# Altium schematic sheet 04-Front Panel SFP_2.SchDoc("04-Front Panel SFP_2")
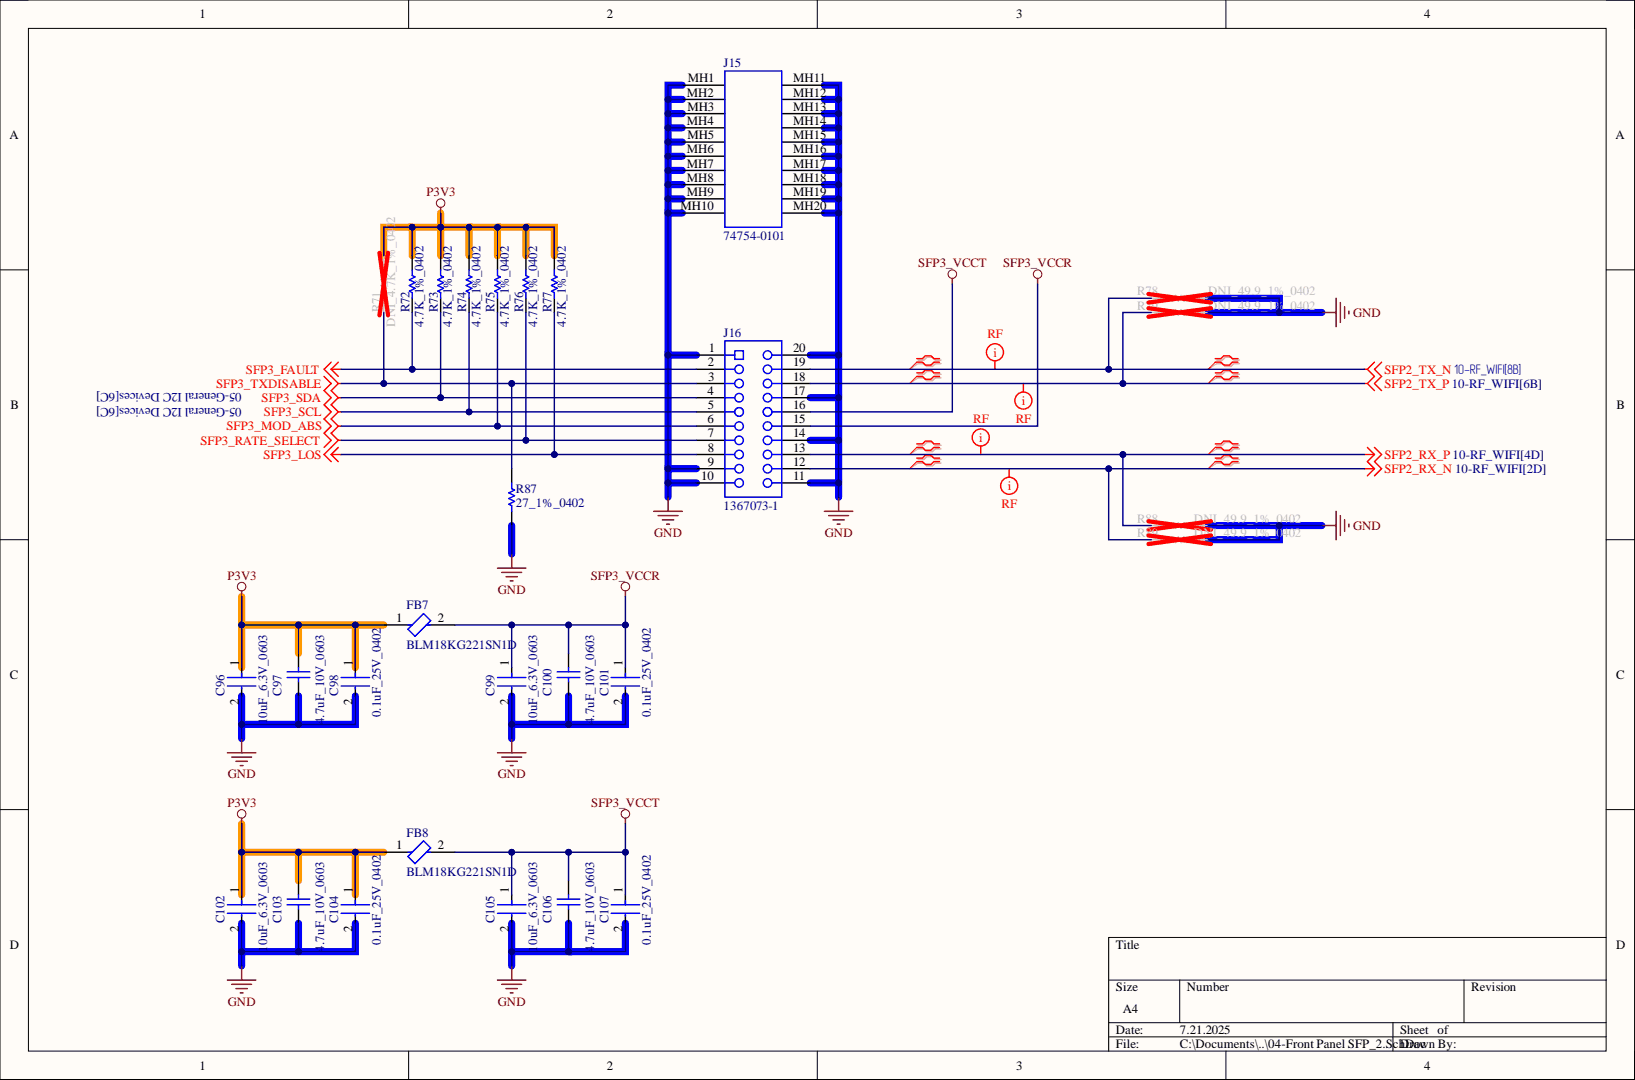
[diagram p0: 04-Front Panel SFP_2.SchDoc]

## Parts
C96  10uF_6.3V_0603  [FP-C0603C106M9PACTU-MFG]
C97  4.7uF_10V_0603  [CAPC1608X87X35NL15T15]
C98  0.1uF_25V_0402  [FP-0402-L_1_0_1-W_0_5_0_1-IPC_C]
C99  10uF_6.3V_0603  [FP-C0603C106M9PACTU-MFG]
C100  4.7uF_10V_0603  [CAPC1608X87X35NL15T15]
C101  0.1uF_25V_0402  [FP-0402-L_1_0_1-W_0_5_0_1-IPC_C]
C102  10uF_6.3V_0603  [FP-C0603C106M9PACTU-MFG]
C103  4.7uF_10V_0603  [CAPC1608X87X35NL15T15]
C104  0.1uF_25V_0402  [FP-0402-L_1_0_1-W_0_5_0_1-IPC_C]
C105  10uF_6.3V_0603  [FP-C0603C106M9PACTU-MFG]
C106  4.7uF_10V_0603  [CAPC1608X87X35NL15T15]
C107  0.1uF_25V_0402  [FP-0402-L_1_0_1-W_0_5_0_1-IPC_C]
FB7  BLM18KG221SN1D  [FP-BLM18-0_15-t0_8-IPC_B]
FB8  BLM18KG221SN1D  [FP-BLM18-0_15-t0_8-IPC_B]
J15  74754-0101  [MOLX-74754-0101_V]
J16  1367073-1  [TECO-1367073-1_V]
R71  DNI_4.7K_1%_0402  [RESC1005X40X25NL10T10]  (no BOM)
R72  4.7K_1%_0402  [RESC1005X40X25NL10T10]
R73  4.7K_1%_0402  [RESC1005X40X25NL10T10]
R74  4.7K_1%_0402  [RESC1005X40X25NL10T10]
R75  4.7K_1%_0402  [RESC1005X40X25NL10T10]
R76  4.7K_1%_0402  [RESC1005X40X25NL10T10]
R77  4.7K_1%_0402  [RESC1005X40X25NL10T10]
R78  DNI_49.9_1%_0402  [RESC1005X40X25NL10T10]  (no BOM)
R79  DNI_49.9_1%_0402  [RESC1005X40X25NL10T10]  (no BOM)
R87  27_1%_0402  [RESC1005X40X25NL05T05]
R88  DNI_49.9_1%_0402  [RESC1005X40X25NL10T10]  (no BOM)
R89  DNI_49.9_1%_0402  [RESC1005X40X25NL10T10]  (no BOM)

## Nets
GND: C96.2 C97.1 C98.2 C99.2 C100.1 C101.2 C102.2 C103.1 C104.2 C105.2 C106.1 C107.2 J15.MH1 J15.MH2 J15.MH3 J15.MH4 J15.MH5 J15.MH6 J15.MH7 J15.MH8 J15.MH9 J15.MH10 J15.MH11 J15.MH12 J15.MH13 J15.MH14 J15.MH15 J15.MH16 J15.MH17 J15.MH18 J15.MH19 J15.MH20 J16.1 J16.9 J16.10 J16.11 J16.14 J16.17 J16.20 R78.2 R79.2 R87.1 R88.2 R89.2
P3V3: C96.1 C97.2 C98.1 C102.1 C103.2 C104.1 FB7.1 FB8.1 R71.1 R72.1 R73.1 R74.1 R75.1 R76.1 R77.1
SFP2_RX_N: J16.12 R89.1
SFP2_RX_P: J16.13 R88.1
SFP2_TX_N: J16.19 R78.1
SFP2_TX_P: J16.18 R79.1
SFP3_FAULT: J16.2 R72.2
SFP3_LOS: J16.8 R77.2
SFP3_MOD_ABS: J16.6 R75.2
SFP3_RATE_SELECT: J16.7 R76.2
SFP3_SCL: J16.5 R74.2
SFP3_SDA: J16.4 R73.2
SFP3_TXDISABLE: J16.3 R71.2 R87.2
SFP3_VCCR: C99.1 C100.2 C101.1 FB7.2 J16.15
SFP3_VCCT: C105.1 C106.2 C107.1 FB8.2 J16.16

## Library data of the parts
C96 C99 C102 C105 = CMP-2006-02285-3: CAP CER 10UF 6.3V X5R 0603; Manufacturer: Kemet; Manufacturer Part Number: C0603C106M9PACTU; Material: Ceramic; Temperature Coefficient: 15%; Tolerance: 20%; Automotive: No; Capacitance: 10uF; Category: Cap; Component Kind: Standard; ComponentLink2Description: Datasheet; ComponentLink2URL: http://www.kemet.com/Lists/ProductCatalog/Attachments/19/KEM_C1006_X5R_SMD.pdf; Device Class L1: Passive Components; Device Class L2: Capacitors; Device Class L3: Ceramic Capacitors; Digikey Description: CAP CER 10UF 6.3V X5R 0603; Height: 0.9mm; Ipc Land Pattern Name: CAPC16080X80; Lead Free: Yes; Library Name: Altium Content Vault; Mouser Description: In a Pack of 10, KEMET 0603 (1608M) 10µF MLCC 6.3V dc ±20% SMD C0603C106M9PACTU; Octopart: https://octopart.com/c0603c106m9pactu-kemet-8375727; Package: C0603C106M9PACTU; RoHS: TRUE; Temperature: -55°C to +85°C; Temperature Characteristic: X5R; Temperature Range High: +85°C; Temperature Range Low: -55°C; Value: 10uF; Voltage Rating: 6.3V
C97 C100 C103 C106 = CMP-2006-03238-1: Manufacturer: Kemet; Part Number: C0603C475K8PACTU; Component Kind: Standard; Library Name: Altium Content Vault
C98 C101 C104 C107 = CMP-2008-02519-2: General Purpose Ceramic Capacitor, 0402, 100nF, 10%, X7R, 15%, 25V; Capacitance: 100nF; Case Code (Imperial): 0402; Case Code (Metric): 1005; Case/Package: 0402; Component Kind: Standard; Construction: Flat; Depth: 0.5mm; Dielectric: X7R; Lead Free: Lead Free; Length: 1mm; Library Name: Altium Content Vault; Max Operating Temperature: 125°C; Min Operating Temperature: -55°C; Mount: Surface Mount; Number of Pins: 2; Package Quantity: 1; Packaging: Tape and Reel; Radiation Hardening: No; REACH SVHC: No SVHC; RoHS Compliant: Yes; Series: -; Termination: SMD/SMT; Thickness: 0.022inch; Tolerance: 10%; Voltage: 25V; Voltage Rating: 25V; Voltage Rating (DC): 25V; Width: 0.02inch
FB7 FB8 = CMP-0686-00556-7: Chip Ferrite Bead, 0603, 220Ω @ 100MHz, 0.05Ω, 25%, 2.2A; DC Resistance (DCR): 50mR; Impedance: 220R; Number of Circuits: 1; Case Code (Imperial): 0603; Case Code (Metric): 1608; Case/Package: 0603; Component Kind: Standard; Core Material: Ferrite; Current Rating: 2.2A; DC Current: 2.2A; DC Resistance-Max: 50mR; Depth: 0.8mm; Height: 0.8mm; Inductor Type: FERRITE BEAD; Lead Free: Lead Free; Length: 1.6mm; Library Name: Altium Content Vault; Material: Ferrite; Max Current Rating: 2.2A; Max DC Current: 2.2A; Max Operating Temperature: 125°C; Military Standard: Not; Min Operating Temperature: -55°C; Number of Lines: 1; Number of Pins: 2; Package Quantity: 1; Packaging: Tape and Reel; Radiation Hardening: No; REACH SVHC: No SVHC; RoHS Compliant: Yes; Series: BLM18K; Termination: SMD/SMT; Test Frequency: 100MHz; Tolerance: 25%; Weight: 7.1E-05oz; Width: 0.8mm
J15 = CMP-1704-00003-3: SFP+ Cage, Single Port, Height 10.85 mm, Tail Height 3.05 mm, -40 to 85 degC, RoHS, Tray; Component Kind: Standard; ComponentLink1Description: Manufacturer URL; ComponentLink1URL: http://www.molex.com/; ComponentLink2Description: Datasheet; ComponentLink2URL: http://www.molex.com/webdocs/datasheets/pdf/en-us/0747540101_IO_CONNECTORS.pdf; ComponentLink3Description: Package Specification; ComponentLink3URL: http://www.molex.com/pdm_docs/sd/747540101_sd.pdf; DatasheetVersion: Rev. Jul-15; Height: 10.85 mm; Length: 15.9 mm; Library Name: Altium Content Vault; Mounting Technology: Through Hole; Package Quantity: 1152; PackageDescription: SFP+ Cage, Single Port, Height 10.85 mm, Tail Height 3.05 mm; PackageVersion: Rev. J, 02/2015; Packaging: Tray; PartNumber: 74754-0101; Radiation Hardening: No; RoHSCompliant: True; Width: 49 mm
J16 = CMP-2000-05488-1: Female Connector, Pitch 0.8 mm, 2 x 10 Position, Height 5.4 mm, -55 to 85 degC, RoHS, Tape and Reel; Manufacturer: TE Connectivity; 3D Model: 1367073-1.pdf; Associated Product: A103997CT-ND  -CONNSFPCAGESINGLEW/OPIPE  A103997TR-ND  -CONNSFPCAGESINGLEW/OPIPE  1367645-3-ND  -CONNCAGE&HEATSINKSGLPORTTIN  1367645-2-ND  -CONNCAGE&HEATSINKSGLPORTTIN  1888424-1-ND  -CONNCAGE1X2SFPENHANCEDEMI  1367643-1-ND  -CONNCAGESNGLPORTDBLSIDETIN  1489962-1-ND  -CONNSFPCAGESINGLEW/OPIPE  1367645-1-ND  -CONNCAGE&HEATSINKSGLPORTTIN  A99375-ND  -CONNSFPCAGE1X4PIN-IN-PASTE  A97412CT-ND  -CONNSFPLOWERCAGEPCB  More...; Connector Style: Receptacle; Connector Type: SFP; Dynamic Catalog: SFPConnectors; Featured Product: HighSpeedPluggableI-OSolutions; Mating Products: 516-2084-ND  -TXRXOPTICALSFF1.25GBDISCSI; Number of Positions: 20; Other Names: A97430DKR; Product Training Modules: HighSpeedPluggableI/OSolutions; Category: Connectors,Interconnects; Component Kind: Standard; Contact Finish: Gold; Contact Finish Thickness: 15µin(0.38µm); Datasheet URL: http://www.te.com/commerce/DocumentDelivery/DDEController?Action=showdoc&DocId=Customer+Drawing%7F1367073%7FK%7Fpdf%7FEnglish%7FENG_CD_1367073_K.pdf%7F1367073-1; Datasheet Version: Rev. K, 12/2012; Family: PluggableConnectors; Library Name: Altium Content Vault; Manufacturer URL: http://www.te.com/; Mounting Technology: SurfaceMount; Mounting Type: SurfaceMount,RightAngle; Package Description: Female Connector, Pitch 0.8 mm, 2 x 10 Position, Height 5.4 mm; Package Reference: 1367073-1; Packaging: Digi-Reel®; RoHS Information: 1367073-1StatementofCompliance; Standard Package: 1; Termination: Solder
R71-R77 = CMP-2002-05217-1: Manufacturer: Bourns; Part Number: CR0402-FX-4701GLF; Library Name: Altium Content Vault
  R72-R77: Component Kind: Standard
R78 R79 R88 R89 = CMP-2002-04448-1: Manufacturer: KOA Speer; Part Number: RK73H1ETTP49R9F; Library Name: Altium Content Vault
R87 = CMP-2002-08434-1: Manufacturer: Vishay Dale; Part Number: CRCW040227R0FKED; Component Kind: Standard; Library Name: Altium Content Vault
